# Altium schematic sheet PantherZVS.SchDoc
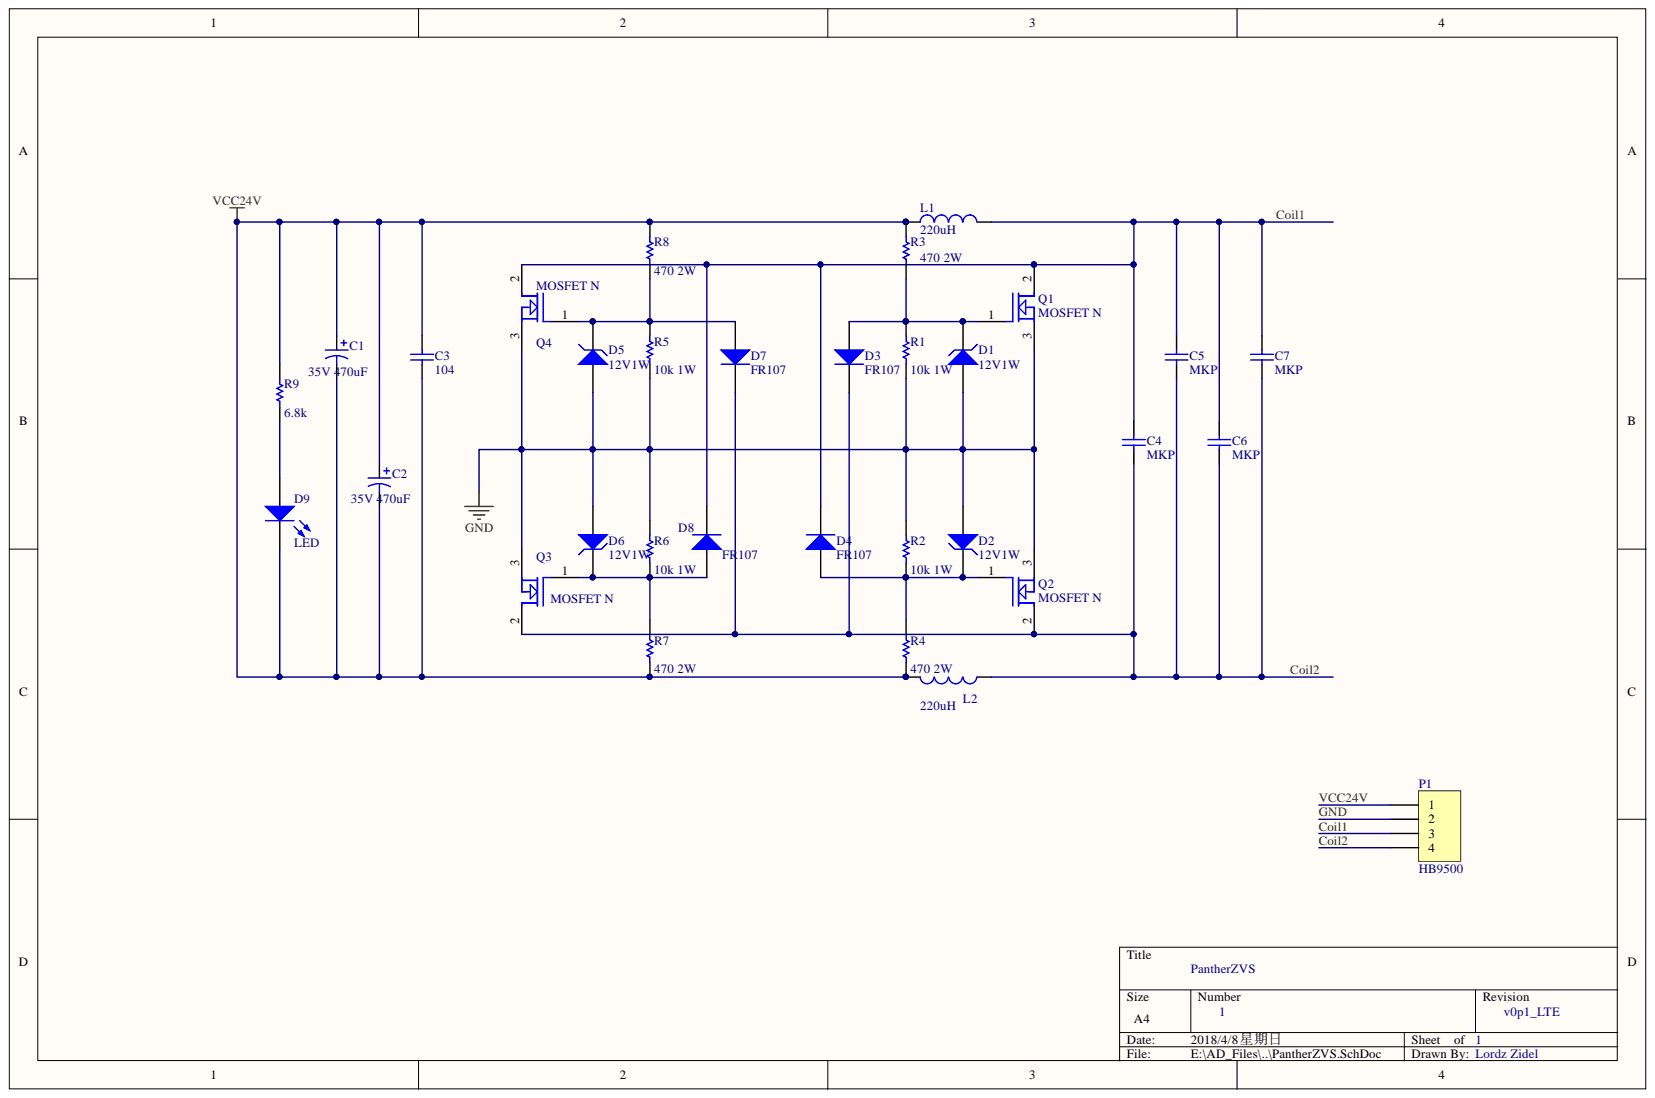
[diagram p0: PantherZVS.SchDoc]

## Parts
C1  value 35V 470uF  [C4_5_10mm]
C2  value 35V 470uF  [C4_5_10mm]
C3  104  [RAD-0.3]
C4  MKP  [MKP_Capacitor]
C5  MKP  [MKP_Capacitor]
C6  MKP  [MKP_Capacitor]
C7  MKP  [MKP_Capacitor]
D1  12V1W  [DIODE-0.4]
D2  12V1W  [DIODE-0.4]
D3  FR107  [DIODE-0.4]
D4  FR107  [DIODE-0.4]
D5  12V1W  [DIODE-0.4]
D6  12V1W  [DIODE-0.4]
D7  FR107  [DIODE-0.4]
D8  FR107  [DIODE-0.4]
D9  LED  [0805]
L1  value 220uH  [HBL_30_10_H]
L2  value 220uH  [HBL_30_10_H]
P1  HB9500  [HB9500_4P]
Q1  MOSFET N  [TO-247AC_ZCEDGE]
Q2  MOSFET N  [TO-247AC_ZCEDGE]
Q3  MOSFET N  [TO-247AC_ZCEDGE]
Q4  MOSFET N  [TO-247AC_ZCEDGE]
R1  value 10k 1W  [1WZC]
R2  value 10k 1W  [1WZC]
R3  value 470 2W  [1WZC]
R4  value 470 2W  [2WZC]
R5  value 10k 1W  [1WZC]
R6  value 10k 1W  [1WZC]
R7  value 470 2W  [2WZC]
R8  value 470 2W  [2WZC]
R9  value 6.8k  [AXIAL-0.3]

## Nets
Coil1: C4.2 C5.2 C6.2 C7.2 D4.2 D8.2 L1.2 P1.3 Q1.2 Q4.2
Coil2: C4.1 C5.1 C6.1 C7.1 D3.2 D7.2 L2.1 P1.4 Q2.2 Q3.2
GND: D1.1 D2.1 D5.1 D6.1 P1.2 Q1.3 Q2.3 Q3.3 Q4.3 R1.1 R2.2 R5.2 R6.1
VCC24V: C1.1 C1.2 C2.1 C2.2 C3.1 C3.2 D9.2 L1.1 L2.2 P1.1 R3.2 R4.1 R7.2 R8.1 R9.2
NetD1_2: D1.2 D3.1 Q1.1 R1.2 R3.1
NetD2_2: D2.2 D4.1 Q2.1 R2.1 R4.2
NetD5_2: D5.2 D7.1 Q4.1 R5.1 R8.2
NetD6_2: D6.2 D8.1 Q3.1 R6.2 R7.1
NetD9_1: D9.1 R9.1

## Library data of the parts
C1 C2 = Cap Pol1: Polarized Capacitor (Radial); Component Kind: Standard; LatestRevisionDate: 17-Jul-2002; LatestRevisionNote: Re-released for DXP Platform.; Library Name: Miscellaneous Devices.IntLib; PackageDescription: Polarized Capacitor (Radial); 2 Leads; PackageReference: RB7.6-15; Published: 8-Jun-2000; Signal Integrity: Cap Pol; Simulation: CAP; Publisher: Altium Limited
C3-C7 = Cap: Capacitor; Component Kind: Standard; LatestRevisionDate: 17-Jul-2002; LatestRevisionNote: Re-released for DXP Platform.; Library Name: Miscellaneous Devices.IntLib; PackageDescription: Radial Cap, Thru-Hole; 2 Leads; 0.3 in Pin Spacing; PackageReference: RAD-0.3; Published: 8-Jun-2000; Signal Integrity: Cap; Simulation: CAP; Publisher: Altium Limited
D1 D2 D5 D6 = D Zener: Zener Diode; Component Kind: Standard; LatestRevisionDate: 17-Jul-2002; LatestRevisionNote: Re-released for DXP Platform.; Library Name: Miscellaneous Devices.IntLib; PackageDescription: Diode, Thru-Hole; 2 Leads; 0.7 in Pin Spacing; PackageReference: DIODE-0.7; Published: 8-Jun-2000; Signal Integrity: D Zener; Simulation: ZENER; Publisher: Altium Limited
D3 D4 D7 D8 = Diode 1N4001: 1 Amp General Purpose Rectifier; Code_JEDEC: DO-204-AL; Component Kind: Standard; ComponentLink1Description: Manufacturer Link; ComponentLink1URL: http://www.fairchildsemi.com/; ComponentLink2Description: Datasheet; ComponentLink2URL: http://www.fairchildsemi.com/ds/1N%2F1N4001.pdf; DatasheetVersion: 1998; LatestRevisionDate: 17-Jul-2002; LatestRevisionNote: Re-released for DXP Platform.; Library Name: Miscellaneous Devices.IntLib; PackageDescription: Diode, Axial; Body 5.2 x 2.7 mm (LxDia. max), Lead Dia. 0.86 mm (max); PackageReference: DO-41; PackageVersion: Aug-1999; Published: 8-Jun-2000; Signal Integrity: 1N4001; Simulation: 1N4001; Publisher: Altium Limited
D9 = LED0: Typical INFRARED GaAs LED; Component Kind: Standard; LatestRevisionDate: 08-Jul-2005; LatestRevisionNote: Mech Layer 1 removed.; Library Name: Miscellaneous Devices.IntLib; PackageDescription: LED; 2 Leads; PackageReference: LED-0; Published: 8-Jun-2000; Simulation: LED0; Publisher: Altium Limited
L1 L2 = Inductor: Code_IEC: 1005; Code_JEITA: 0402; Component Kind: Standard; Description: Inductor; LatestRevisionDate: 29-May-2009; LatestRevisionNote: IPC-7351 Footprint Added.; Library Name: Miscellaneous Devices.IntLib; PackageDescription: Chip Inductor; PackageReference: 0402-A; Published: 8-Jun-2000; Signal Integrity: Inductor; Simulation: INDUCTOR; Publisher: Altium Limited
P1 = Header 4: Header, 4-Pin; Component Kind: Standard; LatestRevisionDate: 17-Jul-2002; LatestRevisionNote: Re-released for DXP Platform.; Library Name: Miscellaneous Connectors.IntLib; Signal Integrity: Connector; Publisher: Altium Limited
Q1-Q4 = MOSFET N: Max Current: 50A; Max Voltage: 200V; Component Kind: Standard; Library Name: 场效应管及可控硅.SCHLIB
R1 R2 R6 = Res1: Resistor; Value: 10k 1W; Component Kind: Standard; LatestRevisionDate: 17-Jul-2002; LatestRevisionNote: Re-released for DXP Platform.; Library Name: Miscellaneous Devices.IntLib; PackageDescription: Axial Device, Thru-Hole; 2 Leads; 0.3 in Pin Spacing; PackageReference: AXIAL-0.3; Published: 8-Jun-2000; Signal Integrity: Res; Simulation: RESISTOR; Publisher: Altium Limited
R3 R4 = Res1: Resistor; Value: 470 2W; Component Kind: Standard; LatestRevisionDate: 17-Jul-2002; LatestRevisionNote: Re-released for DXP Platform.; Library Name: Miscellaneous Devices.IntLib; PackageDescription: Axial Device, Thru-Hole; 2 Leads; 0.3 in Pin Spacing; PackageReference: AXIAL-0.3; Published: 8-Jun-2000; Signal Integrity: Res; Simulation: RESISTOR; Publisher: Altium Limited
R5 R7-R9 = Res1: Resistor; Component Kind: Standard; LatestRevisionDate: 17-Jul-2002; LatestRevisionNote: Re-released for DXP Platform.; Library Name: Miscellaneous Devices.IntLib; PackageDescription: Axial Device, Thru-Hole; 2 Leads; 0.3 in Pin Spacing; PackageReference: AXIAL-0.3; Published: 8-Jun-2000; Signal Integrity: Res; Simulation: RESISTOR; Publisher: Altium Limited
